# Altium schematic sheet H21R4_Backend.SchDoc
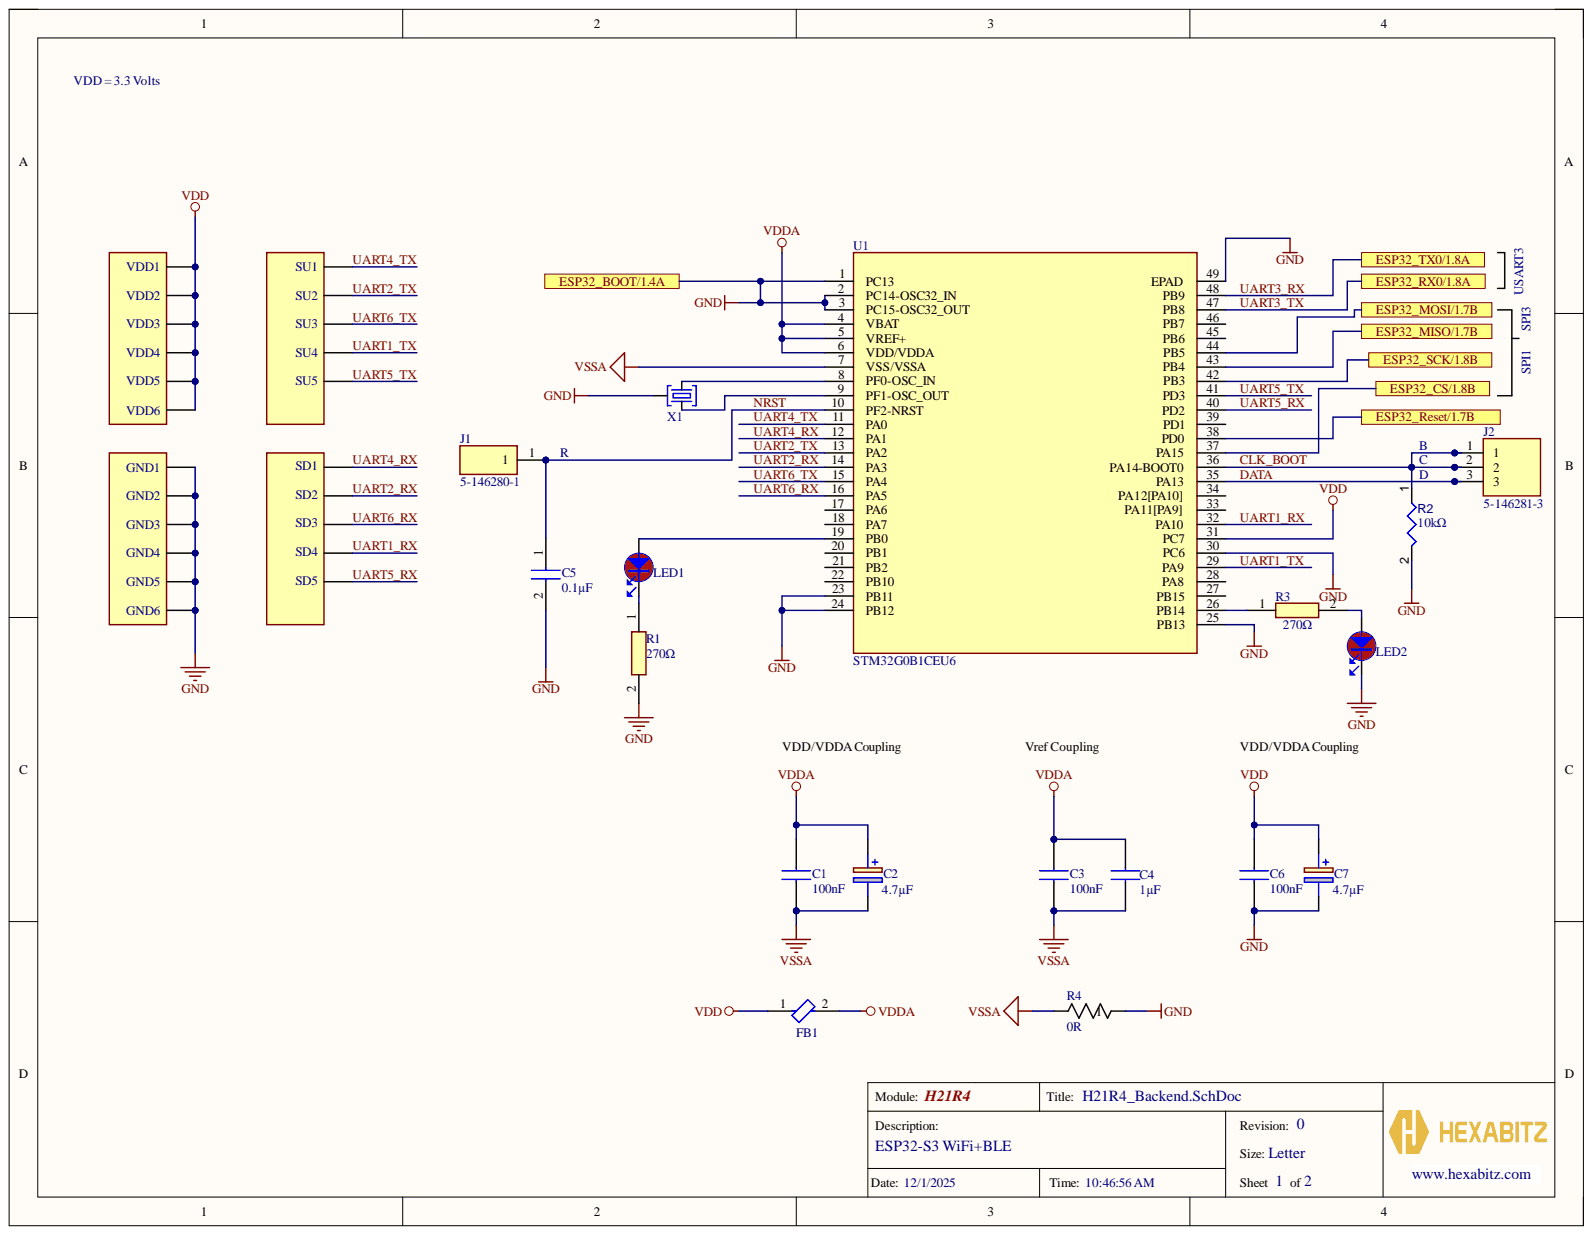
[diagram p0: H21R4_Backend.SchDoc]

## Parts
C1  value 100nF  [CAPC1608X87N]  (hidden comment: C0603C104K8RAC7867)
C2  value 4.7µF  [CAPPM2012X100N]  (hidden comment: 10TPU4R7MSI)
C3  value 100nF  [CAPC1608X87N]  (hidden comment: C0603C104K8RAC7867)
C4  value 1µF  [CAPC2012X145N]  (hidden comment: CC0805KKX7R7BB105)
C5  value 0.1µF  [CAPC1608X87N]  (hidden comment: C0603C104K8RAC7867)
C6  value 100nF  [CAPC1608X87N]  (hidden comment: C0603C104K8RAC7867)
C7  value 4.7µF  [CAPPM2012X100N]  (hidden comment: 10TPU4R7MSI)
FB1  MMZ1608Y300B  [FP-MMZ1608-0_8-MFG]  (value not drawn)
GND1  HEXABIT_UART_PORT  [HEXABIT_POWER_PORT_D]  (value not drawn)  (no BOM)
GND2  HEXABIT_UART_PORT  [HEXABIT_POWER_PORT_D]  (value not drawn)  (no BOM)
GND3  HEXABIT_UART_PORT  [HEXABIT_POWER_PORT_D]  (value not drawn)  (no BOM)
GND4  HEXABIT_UART_PORT  [HEXABIT_POWER_PORT_D]  (value not drawn)  (no BOM)
GND5  HEXABIT_UART_PORT  [HEXABIT_POWER_PORT_D]  (value not drawn)  (no BOM)
GND6  HEXABIT_UART_PORT  [HEXABIT_POWER_PORT_D]  (value not drawn)  (no BOM)
J1  5-146280-1  [HDRV1W67P0X254_1X1_213X234X821P]
J2  5-146281-3  [HDRV3W96P0X254_1X3_721X234X869P]
LED1  VLMS1300-GS08  [FP-6_541-5092_01-4-MFG]  (value not drawn)
LED2  VLMS1300-GS08  [FP-6_541-5092_01-4-MFG]  (value not drawn)
R1  value 270Ω  [ERJ3EKF1000V]  (hidden comment: ERJ-3GEYJ271V)
R2  value 10kΩ  [RESC1608X55X25ML10T15]  (hidden comment: Resistor 10k +/-1% 0603 100 mW)
R3  value 270Ω  [ERJ3EKF1000V]  (hidden comment: ERJ-3GEYJ271V)
R4  value 0R  [YAG_AC0402_YAG]  (hidden comment: AC0402JR-070RL)
SD1  HEXABIT_UART_PORT  [HEXABIT_UART_PORT_D]  (value not drawn)  (no BOM)
SD2  HEXABIT_UART_PORT  [HEXABIT_UART_PORT_D]  (value not drawn)  (no BOM)
SD3  HEXABIT_UART_PORT  [HEXABIT_UART_PORT_D]  (value not drawn)  (no BOM)
SD4  HEXABIT_UART_PORT  [HEXABIT_UART_PORT_D]  (value not drawn)  (no BOM)
SD5  HEXABIT_UART_PORT  [HEXABIT_UART_PORT_D]  (value not drawn)  (no BOM)
SU1  HEXABIT_UART_PORT  [HEXABIT_UART_PORT_U]  (value not drawn)  (no BOM)
SU2  HEXABIT_UART_PORT  [HEXABIT_UART_PORT_U]  (value not drawn)  (no BOM)
SU3  HEXABIT_UART_PORT  [HEXABIT_UART_PORT_U]  (value not drawn)  (no BOM)
SU4  HEXABIT_UART_PORT  [HEXABIT_UART_PORT_U]  (value not drawn)  (no BOM)
SU5  HEXABIT_UART_PORT  [HEXABIT_UART_PORT_U]  (value not drawn)  (no BOM)
U1  STM32G0B1CEU6  [QFN50P700X700X65-49N-D]
VDD1  HEXABIT_UART_PORT  [HEXABIT_POWER_PORT_U]  (value not drawn)  (no BOM)
VDD2  HEXABIT_UART_PORT  [HEXABIT_POWER_PORT_U]  (value not drawn)  (no BOM)
VDD3  HEXABIT_UART_PORT  [HEXABIT_POWER_PORT_U]  (value not drawn)  (no BOM)
VDD4  HEXABIT_UART_PORT  [HEXABIT_POWER_PORT_U]  (value not drawn)  (no BOM)
VDD5  HEXABIT_UART_PORT  [HEXABIT_POWER_PORT_U]  (value not drawn)  (no BOM)
VDD6  HEXABIT_UART_PORT  [HEXABIT_POWER_PORT_U]  (value not drawn)  (no BOM)
X1  CSTCE8M00G52Z-R0  [XTALL_CSTCE8M00G52]  (value not drawn)

## Ports
ESP32_BOOT/1.4A, ESP32_CS/1.8B, ESP32_MISO/1.7B, ESP32_MOSI/1.7B, ESP32_Reset/1.7B, ESP32_RX0/1.8A, ESP32_SCK/1.8B, ESP32_TX0/1.8A

## Nets
VDD: C6.2 C7.1 FB1.1 U1.31(PC7) VDD1.Pwr_U VDD2.Pwr_U VDD3.Pwr_U VDD4.Pwr_U VDD5.Pwr_U VDD6.Pwr_U
VDDA: C1.2 C2.1 C3.2 C4.1 FB1.2 U1.4(VBAT) U1.5(VREF+) U1.6(VDD/VDDA)
VSSA: C1.1 C2.2 C3.1 C4.2 R4.2 U1.7(VSS/VSSA)
CLK_BOOT: J2.1 J2.2 R2.1 U1.36(PA14-BOOT0)
DATA: J2.3 U1.35(PA13)
ESP32_CS/1.8B: U1.37(PA15) ; port ESP32_CS/1.8B
ESP32_MISO/1.7B: U1.43(PB4) ; port ESP32_MISO/1.7B
ESP32_MOSI/1.7B: U1.44(PB5) ; port ESP32_MOSI/1.7B
ESP32_Reset/1.7B: U1.38(PD0) ; port ESP32_Reset/1.7B
ESP32_SCK/1.8B: U1.42(PB3) ; port ESP32_SCK/1.8B
GND: C5.2 C6.1 C7.2 GND1.Pwr_D GND2.Pwr_D GND3.Pwr_D GND4.Pwr_D GND5.Pwr_D GND6.Pwr_D LED2.1 R1.2 R2.2 R4.1 U1.1(PC13) U1.2(PC14-OSC32_IN) U1.3(PC15-OSC32_OUT) U1.23(PB11) U1.24(PB12) U1.25(PB13) U1.30(PC6) U1.49(EPAD) X1.P$2 ; port ESP32_BOOT/1.4A
NRST: C5.1 J1.1 U1.10(PF2-NRST)
UART1_RX: SD4.UART_D U1.32(PA10)
UART1_TX: SU4.UART_U U1.29(PA9)
UART2_RX: SD2.UART_D U1.14(PA3)
UART2_TX: SU2.UART_U U1.13(PA2)
UART3_RX: U1.48(PB9) ; port ESP32_TX0/1.8A
UART3_TX: U1.47(PB8) ; port ESP32_RX0/1.8A
UART4_RX: SD1.UART_D U1.12(PA1)
UART4_TX: SU1.UART_U U1.11(PA0)
UART5_RX: SD5.UART_D U1.40(PD2)
UART5_TX: SU5.UART_U U1.41(PD3)
UART6_RX: SD3.UART_D U1.16(PA5)
UART6_TX: SU3.UART_U U1.15(PA4)
NetLED1_1: LED1.1 R1.1
NetLED1_2: LED1.2 U1.19(PB0)
NetLED2_2: LED2.2 R3.2
NetR3_1: R3.1 U1.26(PB14)
NetU1_8: U1.8(PF0-OSC_IN) X1.P$1
NetU1_9: U1.9(PF1-OSC_OUT) X1.P$3

Not connected: U1 17(PA6) 18(PA7) 20(PB1) 21(PB2) 22(PB10) 27(PB15) 28(PA8) 33(PA11[PA9]) 34(PA12[PA10]) 39(PD1) 45(PB6) 46(PB7)

## Library data of the parts
C1 C3 C6 = CMP-001-00141-1: Multilayer Ceramic Capacitors MLCC - SMD/SMT .1UF     10V   10%        0603; Manufacturer_Name: KEMET; Geometry.Height: 0.87mm; Arrow Part Number: C0603C104K8RAC7867; Arrow Price/Stock: https://www.arrow.com/en/products/c0603c104k8rac7867/kemet-corporation?region=nac; Component Kind: Standard; Datasheet Link: https://labcenter.componentsearchengine.com/Datasheets/1/C0603C104K8RAC7867.pdf; Design Item ID: C0603C104K8RAC7867; Manufacturer_Part_Number: C0603C104K8RAC7867; Mouser Part Number: N/A; Mouser Price/Stock: https://www.mouser.co.uk/ProductDetail/KEMET/C0603C104K8RAC7867?qs=DLNzJaHuXGcLxwK2qWBfDA%3D%3D; Source: C0603C104K8RAC7867.SchLib; Library Name: Wesley Walker
C2 C7 = CMP-001-00143-1: Capacitor Tantalum TPU series 10V 4.7uF Panasonic 4.7uF 10 V dc Tantalum Capacitor Polymer Solid +/-20% tolerance POSCAP series; Geometry.Height: 1mm; Arrow Part Number: 10TPU4R7MSI; Arrow Price/Stock: https://www.arrow.com/en/products/10tpu4r7msi/panasonic; Component Kind: Standard; Datasheet Link: https://industrial.panasonic.com/cdbs/www-data/pdf/AAA8000/AAA8000C28.pdf; Design Item ID: 10TPU4R7MSI; Manufacturer_Name: Panasonic; Manufacturer_Part_Number: 10TPU4R7MSI; Mouser Part Number: 667-10TPU4R7MSI; Mouser Price/Stock: https://www.mouser.com/Search/Refine.aspx?Keyword=667-10TPU4R7MSI; Source: 10TPU4R7MSI.SchLib; Library Name: Wesley Walker
C4 = CMP-001-00144-1: YAGEO - CC0805KKX7R7BB105 - SMD Multilayer Ceramic Capacitor, 1 F, 16 V, 0805 [2012 Metric], +/- 10%, X7R, CC Series; Geometry.Height: 1.45mm; Arrow Part Number: CC0805KKX7R7BB105; Arrow Price/Stock: https://www.arrow.com/en/products/cc0805kkx7r7bb105/yageo?region=nac; Component Kind: Standard; Datasheet Link: https://4donline.ihs.com/images/VipMasterIC/IC/YAGO/YAGO-S-A0003557223/YAGO-S-A0003557223-1.pdf?hkey=6D3A4C79FDBF58556ACFDE234799DDF0; Design Item ID: CC0805KKX7R7BB105; Manufacturer_Name: YAGEO; Manufacturer_Part_Number: CC0805KKX7R7BB105; Mouser Part Number: 603-CC805KKX7R7BB105; Mouser Price/Stock: https://www.mouser.co.uk/ProductDetail/YAGEO/CC0805KKX7R7BB105?qs=AgBp2OyFlx%2FM8WCHgVQGuw%3D%3D; Source: CC0805KKX7R7BB105.SchLib; Library Name: Wesley Walker
C5 = C0603C104K8RAC7867: Capacitor; Manufacturer_Name: KEMET; Arrow Part Number: C0603C104K8RAC7867; Arrow Price/Stock: https://www.arrow.com/en/products/c0603c104k8rac7867/kemet-corporation?region=nac; Component Kind: Standard; Datasheet Link: https://labcenter.componentsearchengine.com/Datasheets/1/C0603C104K8RAC7867.pdf; Height: 0.87mm; Manufacturer_Part_Number: C0603C104K8RAC7867; Mouser Part Number: N/A; Mouser Price/Stock: https://www.mouser.co.uk/ProductDetail/KEMET/C0603C104K8RAC7867?qs=DLNzJaHuXGcLxwK2qWBfDA%3D%3D
FB1 = CMP-006-00027-1: Ferrite Beads 0603 30ohms 1500mA Signal Line AEC-Q200; Manufacturer: TDK; Manufacturer Lifecycle Status: PRODUCTION; Manufacturer Part Number: MMZ1608Y300BTD25; Current Rating: 1.5A; DC Resistance (DCR): 50mO; ESR: 50m; Impedance: 30O; Library: Hexabitz; Number of Circuits: 1; Test Frequency: 100MHz; Tolerance: 25%; Case Code (Metric): 1608; Case/Package: 0603; Category: Ferrite Beads and Chips; Component Kind: Standard; Component Type: Standard; ComponentLink1Description: https://datasheet.octopart.com:443/MMZ1608Y300BTD25-TDK-datasheet-181268448.pdf?src-supplier=IHS; ComponentLink1URL: https://datasheet.octopart.com:443/MMZ1608Y300BTD25-TDK-datasheet-181268448.pdf?src-supplier=IHS; ComponentLink2Description: https://datasheet.octopart.com:443/MMZ1608Y300BTD25-TDK-datasheet-151367874.pdf?src-supplier=TDK; ComponentLink2URL: https://datasheet.octopart.com:443/MMZ1608Y300BTD25-TDK-datasheet-151367874.pdf?src-supplier=TDK; Core Material: Ferrite; Design Item ID: MMZ1608Y300B; Height: 800µm; Length: 1.6mm; Lifecycle Status: Production; Max Current Rating: 1.5A; Max DC Current: 1.5A; Max Length: 1.75mm; Max Operating Temperature: 125°C; Max Width: 950µm; Min Length: 1.45mm; Min Operating Temperature: -55°C; Min Width: 650µm; Mounting Technology: Surface Mount; Number of Lines: 1; Number of Terminals: 2; Packaging: Tape & Reel (TR); Pins: 2; Pricing: 1=0.1, 10=0.06, 25=0.052, 50=0.0468, 100=0.0419, 250=0.03612, 500=0.03214, 1000=0.02847 (USD); Ratings: AEC-Q200; REACH SVHC: Yes; RMS Current (Irms): 1.5A; RoHS: Compliant; Source: Passive.SchLib; Stock: 11896; Termination: SMD/SMT; Weight: 2.012816mg; Width: 800µm; Library Name: Wesley Walker
GND1-GND6 = HEXABIT_POWER_PORT_D: Library Name: hexabitz_connectors.Library.IntLib
J1 = CMP-014-00004-1: AMP - TE CONNECTIVITY - 5-146280-1 - 01 MODII HDR SRST B/A LF; Geometry.Height: 8.21mm; Arrow Part Number: 5-146280-1; Arrow Price/Stock: https://www.arrow.com/en/products/5-146280-1/te-connectivity?region=nac; Component Kind: Standard; Datasheet Link: https://www.te.com/commerce/DocumentDelivery/DDEController?Action=showdoc&DocId=Customer+Drawing%7F146280%7FH2%7Fpdf%7FEnglish%7FENG_CD_146280_H2.pdf%7F5-146280-1; Design Item ID: 5-146280-1; Manufacturer_Name: TE Connectivity; Manufacturer_Part_Number: 5-146280-1; Mouser Part Number: 571-51462801; Mouser Price/Stock: https://www.mouser.co.uk/ProductDetail/TE-Connectivity/5-146280-1?qs=bwpFY26eRVcArW7BMMLddw%3D%3D; Source: 5-146280-1.SchLib; Library Name: Wesley Walker
J2 = 5-146281-3: Connector; Manufacturer_Name: TE Connectivity; Arrow Part Number: 5-146281-3; Arrow Price/Stock: https://www.arrow.com/en/products/5-146281-3/te-connectivity?region=nac; Component Kind: Standard; Datasheet Link: http://www.te.com/commerce/DocumentDelivery/DDEController?Action=showdoc&DocId=Customer+Drawing%7F146281%7FG2%7Fpdf%7FEnglish%7FENG_CD_146281_G2.pdf%7F5-146281-3; Height: 8.69mm; Manufacturer_Part_Number: 5-146281-3; Mouser Part Number: 571-5-146281-3; Mouser Price/Stock: https://www.mouser.co.uk/ProductDetail/TE-Connectivity/5-146281-3?qs=bwpFY26eRVc0RlLXOkMSNw%3D%3D
LED1 LED2 = CMP-007-00039-1: LED Uni-Color Super Red 639nm 2-Pin Chip 0603(1608Metric) T/R; Manufacturer: Vishay Semiconductors; Dominant Wavelength: 631nm; Forward Current: 20mA; Forward Voltage: 2V; Lens Style: Rectangular,Clear; Library: Hexabitz; Luminous Intensity: 54mcd; Number of LEDs: 1; Power Rating: 75mW; Viewing Angle: 130°; Case Code (Metric): 1608; Case/Package: 0603; Category: LEDs; Color: Red; Component Kind: Standard; Component Type: Standard; ComponentLink1Description: https://datasheet.ciiva.com/26322/vlmo1300-26322153.pdf?src-supplier=Element14; ComponentLink1URL: https://datasheet.ciiva.com/26322/vlmo1300-26322153.pdf?src-supplier=Element14; ComponentLink2Description: https://datasheet.ciiva.com/8028/vlmo1300-8028047.pdf?src-supplier=Element14; ComponentLink2URL: https://datasheet.ciiva.com/8028/vlmo1300-8028047.pdf?src-supplier=Element14; ComponentLink3Description: https://datasheet.ciiva.com/9333/vlmo1300-9333743.pdf?src-supplier=Avnet; ComponentLink3URL: https://datasheet.ciiva.com/9333/vlmo1300-9333743.pdf?src-supplier=Avnet; ComponentLink4Description: https://datasheet.ciiva.com/16510/vlmo1300-16510985.pdf?src-supplier=Future+Electronics; ComponentLink4URL: https://datasheet.ciiva.com/16510/vlmo1300-16510985.pdf?src-supplier=Future+Electronics; ComponentLink5Description: https://datasheet.ciiva.com/2114/vlmo1300-2114930.pdf?src-supplier=Digikey; ComponentLink5URL: https://datasheet.ciiva.com/2114/vlmo1300-2114930.pdf?src-supplier=Digikey; ComponentLink6Description: https://datasheet.ciiva.com/2114/vlmo1300-2114931.pdf?src-supplier=Digikey; ComponentLink6URL: https://datasheet.ciiva.com/2114/vlmo1300-2114931.pdf?src-supplier=Digikey; ComponentLink7Description: https://datasheet.ciiva.com/2114/vlmo1300-2114929.pdf?src-supplier=Digikey; ComponentLink7URL: https://datasheet.ciiva.com/2114/vlmo1300-2114929.pdf?src-supplier=Digikey; Design Item ID: VLMS1300-GS08; Height: 0.8mm; Illumination Color: Red; Lead Free: Lead Free; Length: 1.6mm; Manufacturer Part Number: VLMS1300-GS08; Max Operating Temperature: 85°C; Min Operating Temperature: -35°C; Mounting Technology: Surface Mount; Package Quantity: 1; Packaging: Tape and Reel; Pins: 2; Pricing: 1=0.21, 10=0.143, 100=0.0998, 500=0.07982, 1000=0.07322 (USD); Radiation Hardening: No; Reach SVHC Compliant: Unknown; RoHS: Yes; RoHS Compliant: Yes; Series: CHipLED; Source: Semiconductor.SchLib; Stock: 56745; Test Current: 20mA; Wavelength: 631nm; Width: 0.8mm; Library Name: Wesley Walker
R1 R3 = ERJ-3GEYJ271V: Resistor; Manufacturer_Name: Panasonic; Arrow Part Number: ERJ-3GEYJ271V; Arrow Price/Stock: https://www.arrow.com/en/products/erj-3geyj271v/panasonic?region=nac; Component Kind: Standard; Datasheet Link: http://docs-europe.electrocomponents.com/webdocs/13ba/0900766b813ba21b.pdf; Height: 0.55mm; Manufacturer_Part_Number: ERJ-3GEYJ271V; Mouser Part Number: 667-ERJ-3GEYJ271V; Mouser Price/Stock: https://www.mouser.co.uk/ProductDetail/Panasonic/ERJ-3GEYJ271V?qs=gUVpg3Fu0g%2FL9W%252BXBtc%252BCA%3D%3D
R2 = CMP-009-00017-5: Chip Resistor, 10 KOhm, +/- 1%, 01 W, -55 to 155 degC, 0603 (1608 Metric); Power: 100mW; Voltage Rating: 50V; Case/Package: 0603; Component Kind: Standard; Max Operating Temperature: 155°C; Min Operating Temperature: -55°C; Mounting Technology: SM; Pins: 2; RoHS Compliant: True; Tolerance: 1%; Library Name: Wesley Walker
R4 = CMP-009-00179-1: No Description Available; Manufacturer_Name: Yageo; Component Kind: Standard; Design Item ID: AC0402JR-070RL; Manufacturer_Part_Number: AC0402JR-070RL; Source: AC0402JR-070RL.SchLib; Copyright: Copyright (C) 2025 Ultra Librarian. All rights reserved.; Library Name: Wesley Walker
SD1-SD5 = HEXABIT_UART_PORT_D: Library Name: hexabitz_connectors.Library.IntLib
SU1-SU5 = HEXABIT_UART_PORT_U: Library Name: hexabitz_connectors.Library.IntLib
U1 = CMP-031-00010-1: MCU 32-bit ARM Cortex M0+ RISC 512KB Flash 1.8V/2.5V/3.3V 48-Pin UFQFPN EP Tray; Manufacturer: STMicroelectronics; Library: Hexabitz; Category: Microcontrollers; Component Kind: Standard; Component Type: Standard; Design Item ID: STM32G0B1CEU6; Manufacturer Part Number: STM32G0B1CEU6; Pins: 49; Pricing: 1=4.78, 10=3.635, 25=3.3488, 100=3.0347, 260=2.87923, 520=2.7899, 1040=2.71638, 2600=2.63877 (USD); RoHS: Yes; Source: ICs.SchLib; Stock: 4305; Library Name: Wesley Walker
VDD1-VDD6 = HEXABIT_POWER_PORT_U: Library Name: hexabitz_connectors.Library.IntLib
X1 = CMP-031-000013-1: Ceramic Resonator 8MHz ±0.5% (Tol) ±0.2% (Stability) 10pF 40Ohm 3-Pin CSMD T/R; Manufacturer: Murata; Impedance: 40R; Library: Hexabitz; Case Code (Imperial): 1206; Case Code (Metric): 3216; Case/Package: 1206; Category: Capacitors; Component Kind: Standard; Component Type: Standard; Contact Plating: Gold; Design Item ID: CSTCE8M00G52Z-R0; Frequency: 8MHz; Frequency Stability: 0.2%; Frequency Tolerance: 0.5%; Height: 0.031inch; Length: 3.2mm; Load Capacitance: 10pF; Manufacturer Part Number: CSTCE8M00G52Z-R0; Max Operating Temperature: 125°C; Min Operating Temperature: -40°C; Mounting Technology: Surface Mount; Package Quantity: 1; Packaging: Tape and Reel; Pin Count: 3; Pins: 3; RoHS Compliant: Yes; Self Resonant Frequency: 8MHz; Series: CERALOCK®; Source: Passive.SchLib; Termination: SMD/SMT; Tolerance: 0.5%; Value: 10pF; Width: 1.3mm; Library Name: Wesley Walker
